# Altium schematic sheet Power.SchDoc(Power)
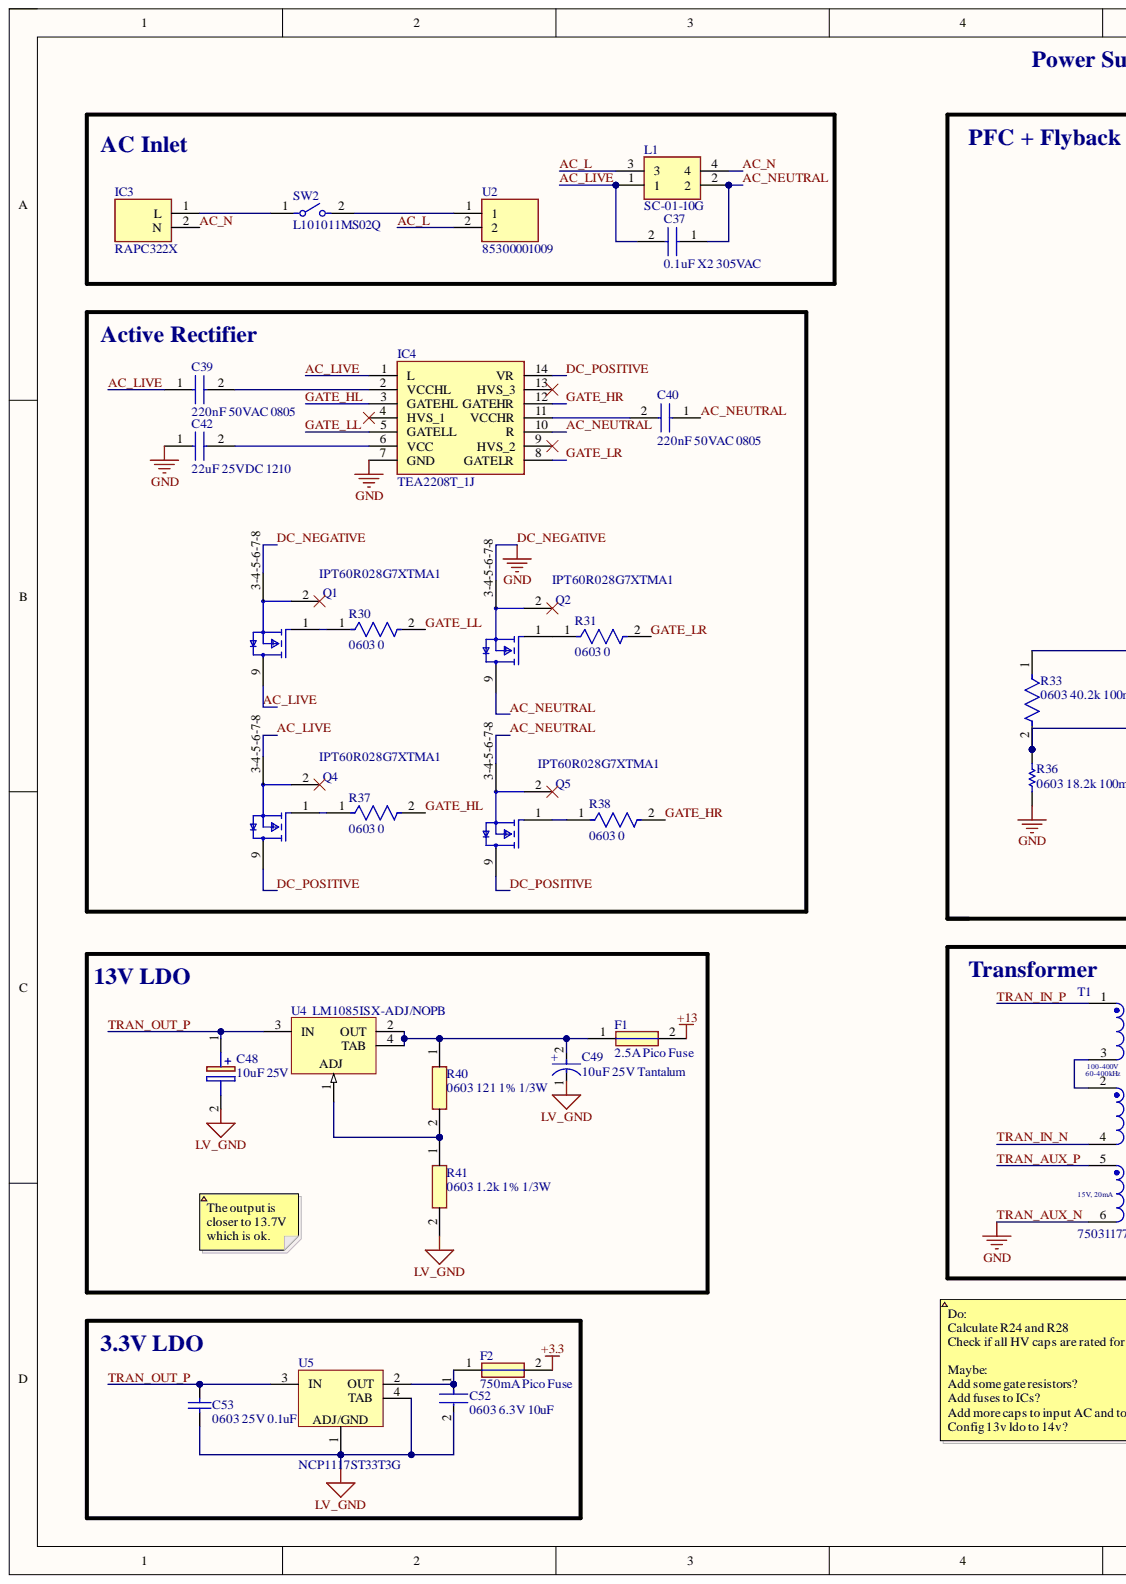
[diagram p0: Power.SchDoc, left]
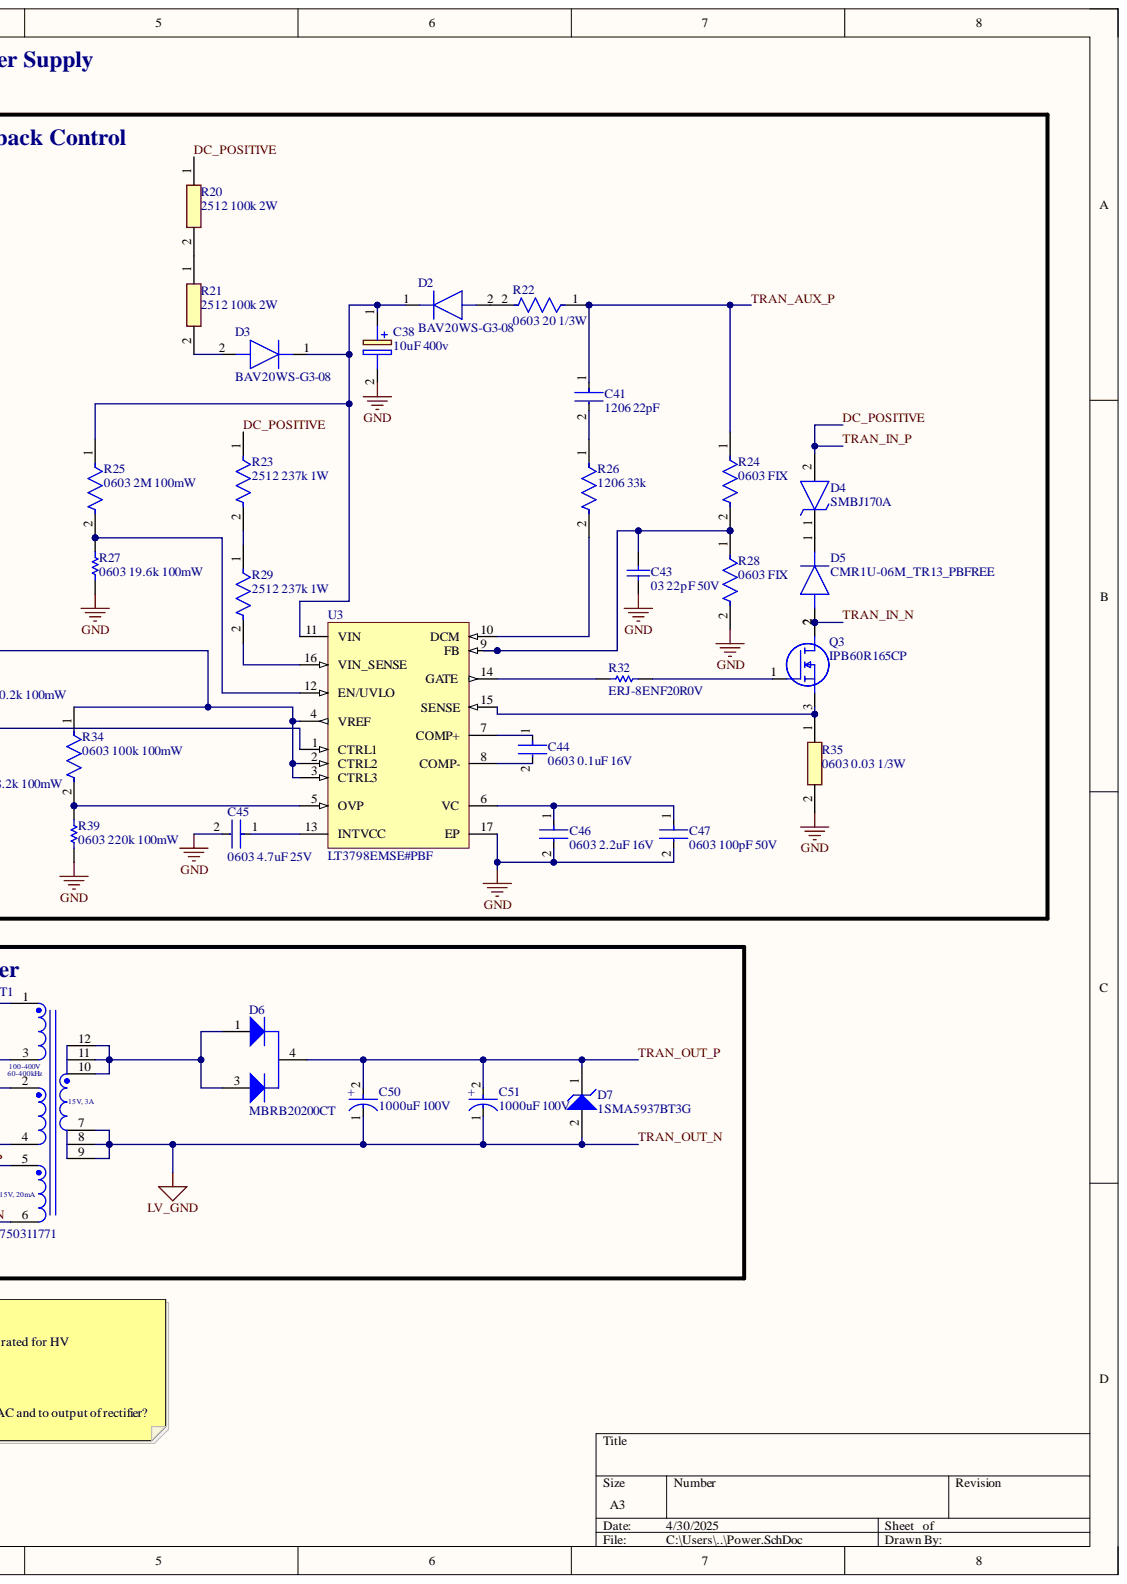
[diagram p1: Power.SchDoc, right]

## Parts
C37  0.1uF X2 305VAC  [B32922C_(18_x_5)]
C38  10uF 400v  [CAPPRD500W60D1025H2150]
C39  220nF 50VAC 0805  [CAPC2012X145N]
C40  220nF 50VAC 0805  [CAPC2012X145N]
C41  1206 22pF  value 22 pF  [FP-C1206C-EB-MFG]
C42  22uF 25VDC 1210  [CAPC3225X200N]
C43  03 22pF 50V  Capacitance: 22pF  [CAPC1608X90X35ML10T15]
C44  0603 0.1uF 16V  [FP-C0603C-CF-MFG]
C45  0603 4.7uF 25V  Capacitance: 4.7uF  Case Code (Imperial): 0603  [FP-GRM188-0_15-IPC_C]
C46  0603 2.2uF 16V  Capacitance: 2.2uF  Case Code (Imperial): 0603  [FP-C0603C225K4PACTU-MFG]
C47  0603 100pF 50V  value 100 pF  [FP-C0603C-CF-MFG]
C48  10uF 25V  [CAPPRD200W55D500H1200]
C49  10uF 25V Tantalum  Capacitance: 10uF  Voltage Rating: 25V  [FP-TAJB-MFG]
C50  1000uF 100V  [FP-RAD-TH-D_16_0_5-L_25_2-MFG]
C51  1000uF 100V  [FP-RAD-TH-D_16_0_5-L_25_2-MFG]
C52  0603 6.3V 10uF  Case Code (Imperial): 0603  Voltage: 6.3V  [FP-GRM188-0_1-e0_2_0_55-IPC_A]
C53  0603 25V 0.1uF  [CAPC1608X87X35LL15T15]
D2  BAV20WS-G3-08  [SOD2713X115N]
D3  BAV20WS-G3-08  [SOD2713X115N]
D4  SMBJ170A  [DIOM5336X244N]
D5  CMR1U-06M_TR13_PBFREE  [DIOM5226X262N]
D6  MBRB20200CT  [ONSC-D2PAK-3-418B-04_V]
D7  1SMA5937BT3G  [ONSC-SMA-2-403D-02_F_V]
F1  2.5A Pico Fuse  [0251004MAT1L]
F2  750mA Pico Fuse  [0251004MAT1L]
IC3  RAPC322X  [RAPC322X]
IC4  TEA2208T_1J  [SOIC127P600X175-14N]
L1  SC-01-10G  [SC0110G]
Q1  IPT60R028G7XTMA1  [FP-PG-HSOF-8-MFG]
Q2  IPT60R028G7XTMA1  [FP-PG-HSOF-8-MFG]
Q3  IPB60R165CP  [IPB60R165CP]
Q4  IPT60R028G7XTMA1  [FP-PG-HSOF-8-MFG]
Q5  IPT60R028G7XTMA1  [FP-PG-HSOF-8-MFG]
R20  2512 100k 2W  [RESC6432X65N]
R21  2512 100k 2W  [RESC6432X65N]
R22  0603 20 1/3W  Case Code (Imperial): 0603  [FP-CRCW0603-HPe3-IPC_B]
R23  2512 237k 1W  Case Code (Imperial): 2512  [FP-CRCW2512-e3-IPC_B]
R24  0603 FIX  Case Code (Imperial): 0603  [FP-CRCW0603-HPe3-MFG]
R25  0603 2M 100mW  Case Code (Imperial): 0603  [FP-CRCW0603-e3-IPC_A]
R26  1206 33k  Case Code (Imperial): 1206  [FP-CRCW1206-e3-IPC_B]
R27  0603 19.6k 100mW  [RESC1609X50X30NL10T20]
R28  0603 FIX  Case Code (Imperial): 0603  [FP-CRCW0603-HPe3-MFG]
R29  2512 237k 1W  Case Code (Imperial): 2512  [FP-CRCW2512-e3-IPC_B]
R30  0603 0  Package: 0603  [FP-ERJ-3GEY0R00V-MFG]
R31  0603 0  Package: 0603  [FP-ERJ-3GEY0R00V-MFG]
R32  ERJ-8ENF20R0V  [RESC3216X70X50NL05T20]
R33  0603 40.2k 100mW  Case Code (Imperial): 0603  [FP-RC0603-0_55-IPC_C]
R34  0603 100k 100mW  Case Code (Imperial): 0603  Max Power Dissipation: 100mW  [FP-RC0603-0_55-MFG]
R35  0603 0.03 1/3W  [ERJ3_B_BQ_BW_LW_(0603)]
R36  0603 18.2k 100mW  [RESC1608X55X30NL15T15]
R37  0603 0  Package: 0603  [FP-ERJ-3GEY0R00V-MFG]
R38  0603 0  Package: 0603  [FP-ERJ-3GEY0R00V-MFG]
R39  0603 220k 100mW  PackageReference: 0603  [RESC1608X55X25ML10T15]
R40  0603 121 1% 1/3W  [RESC1608X55N]
R41  0603 1.2k 1% 1/3W  [RESC1608X55N]
SW2  L101011MS02Q  [FP-L101011MS02Q-MFG]
T1  750311771  Output Current: 3A  [WE-FB_EFD25]
U2  85300001009  [85300001009]
U3  LT3798EMSE#PBF  [LT-MSE-16-EDP_L]
U4  LM1085ISX-ADJ/NOPB  [KTT0003B_M]
U5  NCP1117ST33T3G  [ONSC-SOT-223-4-318H-01_A_V]

## Nets
+3.3: F2.2
+13: F1.2
AC_L: L1.3 U2.2
AC_LIVE: C37.2 C39.1 IC4.1(L) L1.1 Q1.9 Q4.3-4-5-6-7-8
AC_N: IC3.2 L1.4
AC_NEUTRAL: C37.1 C40.1 IC4.10(R) L1.2 Q2.9 Q5.3-4-5-6-7-8
DC_NEGATIVE: C38.2 C42.1 C43.2 C45.2 C46.2 C47.2 IC4.7(GND) Q1.3-4-5-6-7-8 Q2.3-4-5-6-7-8 R27.1 R28.2 R35.2 R36.2 R39.2 T1.6 U3.17(EP)
DC_POSITIVE: D4.2 IC4.14(VR) Q4.9 Q5.9 R20.1 R23.1 T1.1
GATE_HL: IC4.3(GATEHL) R37.2
GATE_HR: IC4.12(GATEHR) R38.2
GATE_LL: IC4.5(GATELL) R30.2
GATE_LR: IC4.8(GATELR) R31.2
TRAN_AUX_P: C41.1 R22.1 R24.1 T1.5
TRAN_IN_N: D5.2 Q3.2 T1.4
TRAN_OUT_N: C48.2 C49.1 C50.1 C51.1 C52.2 C53.2 D7.2 R41.2 T1.7 T1.8 T1.9 U5.1 U5.4(TAB)
TRAN_OUT_P: C48.1 C50.2 C51.2 C53.1 D6.4 D7.1 U4.3(IN) U5.3(IN)
NetC38_1: C38.1 D2.1 D3.1 R25.1 U3.11(VIN)
NetC39_2: C39.2 IC4.2(VCCHL)
NetC40_2: C40.2 IC4.11(VCCHR)
NetC41_2: C41.2 R26.1
NetC42_2: C42.2 IC4.6(VCC)
NetC43_1: C43.1 R24.2 R28.1 U3.9(FB)
NetC44_1: C44.1 U3.7(COMP+)
NetC44_2: C44.2 U3.8(COMP-)
NetC45_1: C45.1 U3.13(INTVCC)
NetC46_1: C46.1 C47.1 U3.6(VC)
NetC49_2: C49.2 F1.1 R40.1 U4.2(OUT) U4.4(TAB)
NetC52_1: C52.1 F2.1 U5.2(OUT)
NetD2_2: D2.2 R22.2
NetD3_2: D3.2 R21.2
NetD4_1: D4.1 D5.1
NetD6_1: D6.1 D6.3 T1.10 T1.11 T1.12
NetIC3_1: IC3.1 SW2.1
NetQ1_1: Q1.1 R30.1
NetQ2_1: Q2.1 R31.1
NetQ3_1: Q3.1 R32.2
NetQ3_3: Q3.3 R35.1 U3.15(SENSE)
NetQ4_1: Q4.1 R37.1
NetQ5_1: Q5.1 R38.1
NetR20_2: R20.2 R21.1
NetR23_2: R23.2 R29.1
NetR25_2: R25.2 R27.2 U3.12(EN/UVLO)
NetR26_2: R26.2 U3.10(DCM)
NetR29_2: R29.2 U3.16(VIN_SENSE)
NetR32_1: R32.1 U3.14(GATE)
NetR33_1: R33.1 R34.1 U3.2(CTRL2) U3.3(CTRL3) U3.4(VREF)
NetR33_2: R33.2 R36.1 U3.1(CTRL1)
NetR34_2: R34.2 R39.1 U3.5(OVP)
NetR40_2: R40.2 R41.1 U4.1
NetSW2_2: SW2.2 U2.1
NetT1_2: T1.2 T1.3

Not connected: IC4 4(HVS_1) 9(HVS_2) 13(HVS_3); Q1 2; Q2 2; Q4 2; Q5 2

## Library data of the parts
C37 = B32922C3104K289: Capacitor; Manufacturer_Name: TDK; Arrow Part Number: B32922C3104K289; Arrow Price/Stock: https://www.arrow.com/en/products/b32922c3104k289/tdk?utm_currency=USD&region=nac; Component Kind: Standard; Datasheet Link: https://product.tdk.com/system/files/dam/doc/product/capacitor/film/emi/data_sheet/20/20/db/fc_2009/x2_b32921_928.pdf; Manufacturer_Part_Number: B32922C3104K289; Mouser Part Number: 871-B32922C3104K289; Mouser Price/Stock: https://www.mouser.co.uk/ProductDetail/EPCOS-TDK/B32922C3104K289?qs=w5o0Loj0u7OxRKa1IZTr8g%3D%3D
C38 = UCS2G100MPD1TD: Capacitor Polarised; Manufacturer_Name: Nichicon; Arrow Part Number: UCS2G100MPD1TD; Arrow Price/Stock: https://www.arrow.com/en/products/ucs2g100mpd1td/nichicon?region=nac; Component Kind: Standard; Datasheet Link: http://nichicon-us.com/english/products/pdfs/e-ucs.pdf; Height: 21.5mm; Manufacturer_Part_Number: UCS2G100MPD1TD; Mouser Part Number: 647-UCS2G100MPD1TD; Mouser Price/Stock: https://www.mouser.co.uk/ProductDetail/Nichicon/UCS2G100MPD1TD?qs=qJYTfuAnAfodP803qrfaZA%3D%3D
C39 C40 = AC0805KFX7R9BB224: Capacitor; Manufacturer_Name: KEMET; Component Kind: Standard; Datasheet Link: http://www.yageo.com/documents/recent/UPY-AC_NP0X7R_16V-to-630V_12.pdf; Height: 1.45mm; Manufacturer_Part_Number: AC0805KFX7R9BB224
C41 = CMP-1037-00989-2: CAP CER 22PF 100V 5% NP0 1206; Manufacturer: KEMET; Manufacturer Part Number: C1206C220J1GACTU; Automotive Grade: Grade 0; Material: Ceramic; Temperature Characteristic: C0G; Temperature Coefficient: 15%; Tolerance: 0.05; Automotive: Yes; Capacitance: 22 pF; Category: Cap; Component Kind: Standard; ComponentLink2Description: Datasheet; ComponentLink2URL: https://content.kemet.com/datasheets/KEM_C1007_X8R_ULTRA_150C_SMD.pdf; Device Class L1: Passive Components; Device Class L2: Capacitors; Device Class L3: Ceramic Capacitors; Digikey Description: CAP CER 22PF 100V 5% NP0 1206; Height: 0.88mm; Ipc Land Pattern Name: CAPC320160X78; Lead Free: Yes; Library Name: Altium Content Vault; Mouser Description: 1206 22pF Ceramic Multilayer Capacitor; 100VDC; 125degC; C0G Dielec; +/-5% SMD; Octopart: https://octopart.com/c1206c220j1gactu-kemet-9145181; Package: C1206C-EB; RoHS: TRUE; Temperature: -55°C to +150°C; Temperature Range High: +150°C; Temperature Range Low: -55°C; Value: 22 pF; Voltage Rating: 100 V
C42 = 25MU225MB23225: Capacitor; Manufacturer_Name: Rubycon; Component Kind: Standard; Datasheet Link: http://www.rubycon.co.jp/en/catalog/e_pdfs/pmlcap/e_MU.pdf; Height: 2mm; Manufacturer_Part_Number: 25MU225MB23225; Mouser Part Number: 232-25MU225MB23225; Mouser Price/Stock: https://www.mouser.co.uk/ProductDetail/Rubycon/25MU225MB23225?qs=T3oQrply3y80th0zXFlwOw%3D%3D
C43 = CMP-2000-04945-1: Chip Capacitor, 22 pF, +/- 5%, 50 V, -55 to 125 degC, 0603 (1608 Metric), RoHS, Tape and Reel; Manufacturer: Murata; Dielectric: C0G; Case Size/Dimension: 0603; Component Kind: Standard; Datasheet URL: https://datasheet.ciiva.com/11786/0900766b807a1cab-11786398.pdf; Datasheet Version: Jul-06; Library Name: Altium Content Vault; Manufacturer URL: http://www.murata.com/; Package Description: 2-Pin Surface Mount Device, Body 1.6 x 0.8 mm; Package Reference: 603; Rated Voltage: 50V; Tolerance (%): ±5%
C44 = CMP-2006-03061-2: CAP CER 0.1UF 50V X7R 0603; Manufacturer: KEMET; Manufacturer Part Number: C0603C104K5RACTU; Automotive Grade: Grade 1; Capacitance: 0.10 μF; Material: Ceramic; Temperature Coefficient: 30ppm/°C; Tolerance: 0.1; Value: 0.10 μF; Automotive: Yes; Category: Cap; Component Kind: Standard; ComponentLink2Description: Datasheet; ComponentLink2URL: https://api.kemet.com/component-edge/download/datasheet/C0603C100J5GACAUTO.pdf; Device Class L1: Passive Components; Device Class L2: Capacitors; Device Class L3: Ceramic Capacitors; Digikey Description: CAP CER 0.1UF 50V X7R 0603; Height: 0.87mm; Lead Free: Yes; Library Name: Altium Content Vault; Octopart: https://octopart.com/c0603c104k5ractu-kemet-139244; Package: C0603C-CF; RoHS: TRUE; Temperature: -55°C to +125°C; Temperature Characteristic: X7R; Temperature Range High: +125°C; Temperature Range Low: -55°C; Voltage Rating: 50 V
C45 = CMP-2006-02523-2: Chip Multilayer Ceramic Capacitors for General Purpose, 0603, 4.7uF, X5R, 15%, 10%, 25V; Case Code (Metric): 1608; Case/Package: 0603; Component Kind: Standard; Depth: 0.8mm; Dielectric: X5R; Height: 0.8mm; Lead Free: Lead Free; Length: 1.6mm; Library Name: Altium Content Vault; Material: Ceramic; Max Operating Temperature: 85°C; Min Operating Temperature: -55°C; Mount: Surface Mount; Package Quantity: 4000; Packaging: Cut Tape; REACH SVHC: No SVHC; Thickness: 0.031inch; Tolerance: 10%; Voltage: 25V; Voltage Rating: 25V; Voltage Rating (DC): 25V; Width: 0.031inch
C46 = CMP-2006-02259-2: CAP CER 2.2UF 16V X5R 0603; Dielectric Material: Ceramic; Dissipation Factor: 5%; Tolerance: 10%; Case Code (Metric): 1608; Case/Package: 0603; Component Kind: Standard; Construction: Flat; Contact Plating: Tin; Depth: 0.8mm; Dielectric: X5R; Height: 0.8mm; Lead Free: Lead Free; Length: 1.6mm; Library Name: Altium Content Vault; Max Operating Temperature: 85°C; Min Operating Temperature: -55°C; Mount: Surface Mount; Number of Pins: 2; Package Quantity: 1; Packaging: Tape and Reel; Radiation Hardening: No; REACH SVHC: No SVHC; RoHS Compliant: Yes; Series: C; Termination: SMD/SMT; Thickness: 0.034inch; Voltage: 16V; Voltage Rating: 16V; Voltage Rating (DC): 16V; Width: 0.8mm
C47 = CMP-2006-03408-2: CAP CER 100PF 50V C0G/NP0 0603; Manufacturer: KEMET; Manufacturer Part Number: C0603C101J5GACTU; Automotive Grade: Grade 1; Material: Ceramic; Temperature Characteristic: C0G; Temperature Coefficient: 30ppm/°C; Tolerance: 0.05; Automotive: Yes; Capacitance: 100 pF; Category: Cap; Component Kind: Standard; ComponentLink2Description: Datasheet; ComponentLink2URL: https://api.kemet.com/component-edge/download/datasheet/C0603C100J5GACAUTO.pdf; Device Class L1: Passive Components; Device Class L2: Capacitors; Device Class L3: Ceramic Capacitors; Digikey Description: CAP CER 100PF 50V C0G/NP0 0603; Height: 0.87mm; Lead Free: Yes; Library Name: Altium Content Vault; Mouser Description: Multilayer Ceramic Capacitor, C Series, 100 Pf, - 5%, C0g / Np0, 50 V, 0603 [1608 Metric] Rohs Compliant: Yes; Octopart: https://octopart.com/c0603c101j5gactu-kemet-100052; Package: C0603C-CF; RoHS: TRUE; Temperature: -55°C to +125°C; Temperature Range High: +125°C; Temperature Range Low: -55°C; Value: 100 pF; Voltage Rating: 50 V
C48 = ECA-1EM100: Capacitor Polarised; Manufacturer_Name: Panasonic; Arrow Part Number: ECA-1EM100; Arrow Price/Stock: https://www.arrow.com/en/products/eca1em100/panasonic; Component Kind: Standard; Datasheet Link: https://componentsearchengine.com/Datasheets/2/ECA-1EM100.pdf; Height: 12mm; Manufacturer_Part_Number: ECA-1EM100; Mouser Part Number: 667-ECA-1EM100; Mouser Price/Stock: https://www.mouser.com/Search/Refine.aspx?Keyword=667-ECA-1EM100
C49 = CMP-04424-000481-1: 10uF 25V  ±10% 2.5Ω 1210  SMD Tantalum Capacitor; Dissipation Factor: 6%; ESR (Equivalent Series Resistance): 2.5R; Leakage Current: 2.5uA; Polarity: Polar; Case Code (Imperial): 1210; Case Code (Metric): 3528; Case/Package: 1210; Component Kind: Standard; Construction: Flat; Depth: 2.8mm; Features: General Purpose; Height: 2.1mm; Height - Seated (Max): 0.083inch; Lead Free: Lead Free; Length: 3.5mm; Library Name: Altium Content Vault; Max Operating Temperature: 125°C; Min Operating Temperature: -55°C; Mount: Surface Mount; Package Quantity: 1; Packaging: Tape and Reel; Radiation Hardening: No; REACH SVHC: No SVHC; RoHS Compliant: Yes; Series: TAJ; Termination: SMD/SMT; Tolerance: 10%; Voltage Rating (DC): 25V; Weight: 0.000564oz; Width: 0.11inch
C50 C51 = CMP-05427-000487-1: Aluminum Electrolytic Capacitors (Radial Lead Type) 1000uF ±20% 50V; Capacitance: 1mF; ESR (Equivalent Series Resistance): 16mR; Lead Diameter: 0.8mm; Life (Hours): 10000Hour; Polarity: Polar; Ripple Current: 3.32A; Case/Package: Radial; Component Kind: Standard; Contact Plating: Tin; Diameter: 16mm; Features: General Purpose; Height: 25mm; Height - Seated (Max): 0.984inch; Impedance: 16mR; Lead Free: Lead Free; Lead Pitch: 7.5mm; Length: 25mm; Library Name: Altium Content Vault; Max Operating Temperature: 105°C; Min Operating Temperature: -40°C; Mount: Through Hole; Number of Pins: 2; Package Quantity: 250; Packaging: Tape and Reel; Radiation Hardening: No; REACH SVHC: No SVHC; RoHS Compliant: Yes; Series: FR; Termination: Through Hole; Tolerance: 20%; Voltage Rating: 50V; Voltage Rating (DC): 50V
C52 = CMP-2006-02792-2: Chip Multilayer Ceramic Capacitors for General Purpose, 0603, 10uF, X5R, 15%, 20%, 6.3V; Capacitance: 10uF; Case Code (Metric): 1608; Case/Package: 0603; Component Kind: Standard; Depth: 0.8mm; Dielectric: X5R; Height: 0.8mm; Lead Free: Lead Free; Length: 1.6mm; Library Name: Altium Content Vault; Material: Ceramic; Max Operating Temperature: 85°C; Min Operating Temperature: -55°C; Mount: Surface Mount; Package Quantity: 4000; Packaging: Tape and Reel; Radiation Hardening: No; REACH SVHC: No SVHC; Termination: SMD/SMT; Thickness: 0.035inch; Tolerance: 20%; Voltage Rating: 6.3V; Voltage Rating (DC): 6.3V; Width: 0.031inch
C53 = CMP-2006-03713-1: Manufacturer: Kemet; Part Number: C0603C105K3RACTU; Component Kind: Standard; Library Name: Altium Content Vault
D2 D3 = BAV20WS-G3-08: Diode; Manufacturer_Name: Vishay; Arrow Part Number: BAV20WS-G3-08; Arrow Price/Stock: https://www.arrow.com/en/products/bav20ws-g3-08/vishay?region=nac; Component Kind: Standard; Datasheet Link: https://componentsearchengine.com/Datasheets/1/BAV20WS-G3-08.pdf; Height: 1.15mm; Library Name: SamacSys.SchLib; Manufacturer_Part_Number: BAV20WS-G3-08; Mouser Part Number: 78-BAV20WS-G3-08; Mouser Price/Stock: https://www.mouser.co.uk/ProductDetail/Vishay-Semiconductors/BAV20WS-G3-08?qs=ROh%252BA47s9d2Jk9K4pPeq%252BQ%3D%3D
D4 = SMBJ170A: TVS Diode (Uni-directional); Manufacturer_Name: HY Electronic; Component Kind: Standard; Height: 2.44mm; Library Name: SamacSys.SchLib; Manufacturer_Part_Number: SMBJ170A
D5 = CMR1U-06M_TR13_PBFREE: Diode; Manufacturer_Name: Central Semiconductor; Manufacturer_Part_Number: CMR1U-06M TR13 PBFREE; Component Kind: Standard; Datasheet Link: https://my.centralsemi.com/datasheets/CMR1U01M.PDF; Height: 2.62mm; Library Name: SamacSys.SchLib; Mouser Part Number: 610-CMR1U-06M; Mouser Price/Stock: https://www.mouser.co.uk/ProductDetail/Central-Semiconductor/CMR1U-06M-TR13-PBFREE/?qs=u16ybLDytRaiTbcu0RAPhw%3D%3D
D6 = CMP-1055-00130-1: SWITCHMODE(TM) Power Rectifier, Dual Schottky Rectifiers, 3-Pin D2PAK, Tube; Manufacturer: On Semiconductor; Configuration: Common Cathode; IFSM Max (A): 150; Note1: 0; Component Kind: Standard; ComponentLink1Description: Manufacturer URL; ComponentLink1URL: http://www.onsemi.com/; ComponentLink2Description: Datasheet; ComponentLink2URL: http://www.onsemi.cn/pub_link/Collateral/MBRB20200CT-D.PDF; ComponentLink3Description: Package Specification; ComponentLink3URL: http://www.onsemi.com/pub_link/Collateral/418B-04.PDF; DatasheetVersion: Rev. 7; Library Name: Altium Content Vault; Mounting Technology: Surface Mount; PackageDescription: 3-Pin Flange Mount, Body 9.145 x 9.97 mm, Pitch 2.54 mm; PackageReference: D2PAK-3-418B-04; PackageVersion: Rev. K, 09/2008; Packing: Tube; PartNumber: MBRB20200CT; RoHS: FALSE
D7 = CMP-1060-00094-1: 1.5 W Zener Voltage Regulator, 2-Pin SMA, Pb-Free, Tape and Reel; Manufacturer: On Semiconductor; VZ Typ (V): 33; Component Kind: Standard; ComponentLink1Description: Manufacturer URL; ComponentLink1URL: http://www.onsemi.com/; ComponentLink2Description: Datasheet; ComponentLink2URL: http://www.onsemi.com/pub_link/Collateral/1SMA5913BT3-D.PDF; ComponentLink3Description: Package Specification; ComponentLink3URL: http://www.onsemi.com/pub_link/Collateral/1SMA5913BT3-D.PDF; DatasheetVersion: Rev. 8; Library Name: Altium Content Vault; Mounting Technology: Surface Mount; PackageDescription: 2-Pin Small Molded Package, J-Bend Lead, Body 2.605 x 4.315 mm; PackageReference: SMA-2-403D-02_F; PackageVersion: Rev. F, 11/2008; Packing: Tape and Reel; PartNumber: 1SMA5937BT3G; RoHS: Pb-Free
F1 F2 = 0251004.MAT1L: Fuse; Manufacturer_Name: LITTELFUSE; Arrow Part Number: 0251004.MAT1L; Arrow Price/Stock: https://www.arrow.com/en/products/0251004.mat1l/littelfuse?utm_currency=USD&region=nac; Component Kind: Standard; Datasheet Link: https://www.littelfuse.com/media?resourcetype=datasheets&itemid=f47a0bb7-8ede-4679-9646-7114c3787688&filename=littelfuse-fuse-251-253-datasheet; Height: 2.8mm; Manufacturer_Part_Number: 0251004.MAT1L; Mouser Part Number: 576-0251004.MAT1L; Mouser Price/Stock: https://www.mouser.co.uk/ProductDetail/Littelfuse/0251004.MAT1L?qs=vco6xy%252BeH1onbv8s0e1iMg%3D%3D
IC3 = RAPC322X: Integrated Circuit; Manufacturer_Name: Switchcraft; Arrow Part Number: RAPC322X; Arrow Price/Stock: https://www.arrow.com/en/products/rapc322x/switchcraft?region=nac; Component Kind: Standard; Datasheet Link: http://www.switchcraft.com/Drawings/RAPC322X_CD.PDF; Height: 13mm; Manufacturer_Part_Number: RAPC322X; Mouser Part Number: 502-RAPC322X; Mouser Price/Stock: https://www.mouser.co.uk/ProductDetail/Switchcraft/RAPC322X?qs=mcPJWgAPNrcmmHrLtKpxWA%3D%3D
IC4 = TEA2208T_1J: Integrated Circuit; Manufacturer_Name: NXP; Manufacturer_Part_Number: TEA2208T/1J; Component Kind: Standard; Datasheet Link: https://www.nxp.com/docs/en/data-sheet/TEA2208T.pdf; Height: 1.75mm; Mouser Part Number: 771-TEA2208T/1J; Mouser Price/Stock: https://www.mouser.co.uk/ProductDetail/NXP-Semiconductors/TEA2208T-1J?qs=wnTfsH77Xs7i9IS7OBVq2w%3D%3D
L1 = SC-01-10G: Inductor; Manufacturer_Name: KEMET; Component Kind: Standard; Datasheet Link: https://content.kemet.com/datasheets/KEM_LF0011_SC-G-GS.pdf; Height: 23mm; Manufacturer_Part_Number: SC-01-10G; Mouser Part Number: 80-SC-01-10G; Mouser Price/Stock: https://www.mouser.co.uk/ProductDetail/KEMET/SC-01-10G?qs=BenOyfdfArpzDVRBtNEKMw%3D%3D
Q1 Q2 Q4 Q5 = CMP-32901-000081-1: MOSFET N-CH 600V 75A HSOF-8; Manufacturer: Infineon; Depletion Mode: False; Drain To Source Resistance: 0.024Ω; Drain To Source Voltage: 650V; Gate Charge At Vgs: 123nC @ 10V; Gate To Source Voltage: 20V; Input Capacitace At Vds: 4820pF @ 400V; Max Junction Temp: +150°C; Number Of Channels: 1; Power Dissipation: 391W; Pulse Drain Current: 245A; Reverse Recovery Charge: 8700nC; Reverse Recovery Time: 440ns; Rthja Max: 62°C/W; Threshold Vgs Max: 4V; Threshold Vgs Min: 3V; Turn Off Delay Time: 100ns; Turn On Delay Time: 28ns; Typ Forward Diode Voltage: 0.8V; Automotive: No; Category: Trans; Component Kind: Standard; ComponentLink2Description: Datasheet; ComponentLink2URL: https://www.infineon.com/dgdl/Infineon-IPT60R028G7-DS-v02_00-EN.pdf?fileId=5546d46259d9a4bf015a13e82df70e30; Continuous Drain Current: 75A; Device Class L1: Discrete Semiconductors; Device Class L2: Transistors; Device Class L3: MOSFETs; Digikey Description: MOSFET N-CH 600V 75A HSOF-8; Drain To Source Breakdown Voltage: 600V; Footprint Url: https://www.infineon.com/cms/en/product/packages/PG-HSOF/PG-HSOF-8-2/; Height: 2.4mm; Lead Free: Yes; Library Name: Altium Content Vault; Manufacturer Part Number: IPT60R028G7XTMA1; Mouser Description: Trans MOSFET N-CH 600V 75A 9-Pin(8+Tab) HSOF T/R; Number Of N Channels: 1; Octopart: https://octopart.com/ipt60r028g7xtma1-infineon-76958210; Package: PG-HSOF-8; RoHS: TRUE; Temperature: -55°C to +150°C; Temperature Range High: +150°C; Temperature Range Low: -55°C
Q3 = IPB60R165CP: MOSFET (N-Channel); Manufacturer_Name: Infineon; Component Kind: Standard; Datasheet Link: https://www.infineon.com/dgdl/Infineon-IPB60R165CP-DS-v02_01-en.pdf?fileId=db3a304412b407950112b42dd38f48fc; Height: 4.826mm; Library Name: SamacSys.SchLib; Manufacturer_Part_Number: IPB60R165CP; Mouser Part Number: 726-IPB60R165CP; Mouser Price/Stock: https://www.mouser.co.uk/ProductDetail/Infineon-Technologies/IPB60R165CP?qs=mzcOS1kGbgdcrfUvmAosLQ%3D%3D
R20 R21 = 9-2176070-7: Resistor; Manufacturer_Name: TE Connectivity; Component Kind: Standard; Datasheet Link: https://www.te.com/commerce/DocumentDelivery/DDEController?Action=srchrtrv&DocNm=9-1773463-5&DocType=Data+Sheet&DocLang=English&PartCntxt=9-2176070-7&DocFormat=pdf; Height: 0.65mm; Manufacturer_Part_Number: 9-2176070-7; Mouser Part Number: 279-9-2176070-7; Mouser Price/Stock: https://www.mouser.co.uk/ProductDetail/TE-Connectivity-Holsworthy/9-2176070-7/?qs=IXkKOuu5vnGY%252Bze3ajKOBA%3D%3D
R22 = CMP-2000-03074-2: RES Thick Film, 20Ω, 1%, 0.33W, 100ppm/°C, 0603; Max Power Dissipation: 250mW; Resistance: 20R; Voltage Rating: 75V; Case Code (Metric): 1608; Case/Package: 0603; Component Kind: Standard; Composition: Thick Film; Contact Plating: Tin; Depth: 0.85mm; Features: Automotive AEC-Q200; Height: 0.45mm; Lead Free: Lead Free; Length: 1.6mm; Library Name: Altium Content Vault; Max Operating Temperature: 155°C; Min Operating Temperature: -55°C; Mount: Surface Mount; Number of Terminations: 2; Package Quantity: 10; Packaging: Tape and Reel; Power Rating: 250mW; Radiation Hardening: No; Temperature Coefficient: 100ppm/°C; Termination: Wraparound; Tolerance: 1%; Weight: 7.1E-05oz; Width: 0.033inch
R23 R29 = CMP-02407-005674-1: RES Thick Film, 237kΩ, 1%, 1W, 100ppm/°C, 2512; Resistance: 237kR; Voltage Rating (DC): 500V; Case Code (Metric): 6432; Case/Package: 2512; Component Kind: Standard; Composition: Thick Film; Features: Automotive AEC-Q200; Height: 0.028inch; Lead Free: Lead Free; Length: 0.248inch; Library Name: Altium Content Vault; Max Power Dissipation: 1W; Number of Terminations: 2; Package Quantity: 2000; Packaging: Tape and Reel; Temperature Coefficient: 100ppm/°C; Tolerance: 1%; Width: 0.124inch
R24 R28 = CMP-2000-03817-2: RES Thick Film, 0Ω, , 0.33W, , 0603; Max Power Dissipation: 250mW; Resistance: 0mR; Temperature Coefficient: 0ppm/°C; Voltage Rating: 75V; Case Code (Metric): 1608; Case/Package: 0603; Component Kind: Standard; Composition: Thick Film; Contact Plating: Tin; Depth: 0.85mm; Features: Automotive AEC-Q200; Height: 0.45mm; Lead Free: Lead Free; Length: 1.6mm; Library Name: Altium Content Vault; Max Operating Temperature: 155°C; Military Standard: Not; Min Operating Temperature: -55°C; Mount: Surface Mount; Number of Pins: 2; Number of Terminations: 2; Package Quantity: 5000; Packaging: Tape and Reel; Power Rating: 250mW; Radiation Hardening: No; Termination: SMD/SMT; Voltage Rating (DC): 75V; Weight: 7.1E-05oz; Width: 0.033inch
R25 = CMP-2000-03189-2: RES Thick Film, 2MΩ, 1%, 0.1W, 100ppm/°C, 0603; Resistance: 2MR; Voltage Rating: 75V; Case Code (Metric): 1608; Case/Package: 0603; Component Kind: Standard; Composition: Thick Film; Contact Plating: Tin; Depth: 0.85mm; Features: Automotive AEC-Q200; Height: 0.45mm; Lead Free: Lead Free; Length: 1.55mm; Library Name: Altium Content Vault; Max Operating Temperature: 155°C; Max Power Dissipation: 100mW; Military Standard: Not; Min Operating Temperature: -55°C; Number of Pins: 2; Number of Terminations: 2; Package Quantity: 10; Packaging: Tape and Reel; Power Rating: 100mW; Radiation Hardening: No; Temperature Coefficient: 100ppm/°C; Termination: SMD/SMT; Tolerance: 1%; Voltage Rating (DC): 75V; Weight: 7.1E-05oz; Width: 0.033inch; Working Voltage: 75V
R26 = CMP-2003-02103-2: RES Thick Film, 33kΩ, 1%, 0.25W, 100ppm/°C, 1206; Max Power Dissipation: 250mW; Resistance: 33kR; Voltage Rating: 200V; Case Code (Metric): 3216; Case/Package: 1206; Component Kind: Standard; Composition: Thick Film; Contact Plating: Tin; Depth: 1.6mm; Features: Automotive AEC-Q200; Height: 0.55mm; Lead Free: Lead Free; Length: 3.2mm; Library Name: Altium Content Vault; Max Operating Temperature: 155°C; Military Standard: Not; Min Operating Temperature: -55°C; Number of Terminations: 2; Package Quantity: 10; Packaging: Tape and Reel; Power Rating: 250mW; Radiation Hardening: No; Temperature Coefficient: 100ppm/°C; Termination: SMD/SMT; Tolerance: 1%; Voltage Rating (DC): 200V; Weight: 0.000353oz; Width: 1.6mm; Working Voltage: 200V
R27 = CMP-2000-02969-1: Manufacturer: Vishay Dale; Part Number: CRCW060319K6FKEA; Component Kind: Standard; Library Name: Altium Content Vault
R30 R31 R37 R38 = CMP-2000-00700-2: RES SMD 0 OHM JUMPER 1/10W 0603; Manufacturer: Panasonic; Manufacturer Part Number: ERJ-3GEY0R00V; Automotive Grade: Grade 0; Power Rating: 0.1W; Resistance: 0Ω; Temperature Coefficient: -100/+600ppm/°C; Tolerance: 5%; Value: 0Ω; Voltage Rating: 75V; Automotive: Yes; Category: Res; Component Kind: Standard; ComponentLink2Description: Datasheet; ComponentLink2URL: https://industrial.panasonic.com/cdbs/www-data/pdf/RDA0000/AOA0000C301.pdf; Composition: Thick Film; Device Class L1: Passive Components; Device Class L2: Resistors; Device Class L3: Chip SMD Resistors; Digikey Description: RES SMD 0 OHM JUMPER 1/10W 0603; Footprint Url: https://industrial.panasonic.com/cdbs/www-data/pdf/RDA0000/DMA0000COL17.pdf; Height: 0.55mm; Ipc Land Pattern Name: RESC16080X45; Lead Free: Yes; Library Name: Altium Content Vault; Mouser Description: Resistor, Chip Thick Film, 0 Ohm, 0.1w, Resistor, Chip Thick Film, 0 Ohm, 0.1w, 5%; Octopart: https://octopart.com/erj-3gey0r00v-panasonic-55389238; RoHS: TRUE; Series: ERJ; Temperature: -55°C to +155°C; Temperature Range High: +155°C; Temperature Range Low: -55°C
R32 = CMP-2003-00203-1: Manufacturer: Panasonic; Component Kind: Standard; Library Name: Altium Content Vault; Part Number: ERJ-8ENF20R0V
R33 = CMP-03412-037816-1: RES 40.2K OHM 1% 1/10W 0603; Resistance: 40.2kR; Temperature Coefficient: 100ppm/°C; Voltage Rating: 50V; Working Voltage: 75V; Case Code (Metric): 1608; Case/Package: 0603; Component Kind: Standard; Construction: Rectangular; Depth: 0.8mm; Features: Moisture Resistant; Height: 0.45mm; Lead Free: Lead Free; Length: 1.6mm; Library Name: Altium Content Vault; Max Operating Temperature: 155°C; Max Power Dissipation: 100mW; Military Standard: Not; Min Operating Temperature: -55°C; Mount: Surface Mount; Number of Terminations: 2; Packaging: Tape and Reel; Power Rating: 100mW; Resistor Type: Thick Film; RoHS Compliant: Yes; Series: RC; Technology: METAL OXIDE FILM; Termination: SMD/SMT; Tolerance: 1%; Voltage Rating (DC): 50V; Width: 0.8mm
R34 = CMP-2100-03662-2: RES SMD 100K OHM 1% 1/10W 0603; Resistance: 100kR; Temperature Coefficient: 100ppm/°C; Voltage Rating: 50V; Working Voltage: 75V; Case Code (Metric): 1608; Case/Package: 0603; Component Kind: Standard; Construction: Rectangular; Depth: 0.8mm; Features: Moisture Resistant; Height: 0.45mm; Lead Free: Lead Free; Length: 1.6mm; Library Name: Altium Content Vault; Max Operating Temperature: 155°C; Military Standard: Not; Min Operating Temperature: -55°C; Number of Pins: 2; Number of Terminations: 2; Packaging: Tape and Reel; Power Rating: 100mW; REACH SVHC: No SVHC; Resistance Tolerance: 1%; Resistor Type: Thick Film; RoHS Compliant: Yes; Series: RC; Technology: THICK FILM; Termination: SMD/SMT; Tolerance: 1%; Voltage Rating (DC): 50V; Width: 0.8mm
R35 = ERJ-3BWFR030V: Resistor; Manufacturer_Name: Panasonic; Component Kind: Standard; Datasheet Link: http://industrial.panasonic.com/cdbs/www-data/pdf/RDN0000/AOA0000C313.pdf; Manufacturer_Part_Number: ERJ-3BWFR030V; Mouser Part Number: 667-ERJ-3BWFR030V; Mouser Price/Stock: https://www.mouser.co.uk/ProductDetail/Panasonic/ERJ-3BWFR030V?qs=ob%252BdNz2%252BYEgeP2PEealD%252Bg%3D%3D
R36 = CMP-2000-00300-1: Manufacturer: Panasonic; Part Number: ERJ-3EKF1822V; Component Kind: Standard; Library Name: Altium Content Vault
R39 = CMP-1659-00037-1: Chip Resistor, 220 KOhm, +/- 1%, 100 mW, -55 to 155 degC, 0603 (1608 Metric), RoHS, Tape and Reel; Resistance: 0.1 Ohm; Component Kind: Standard; ComponentLink1Description: Manufacturer URL; ComponentLink1URL: http://www.yageo.com/; ComponentLink2Description: Datasheet; ComponentLink2URL: http://www.yageo.com/documents/recent/PYu-RC_Group_51_RoHS_L_04.pdf; DatasheetVersion: Rev. 4, 02/2016; Height: 0.25 inch; Length: 0.75 inch; Library Name: Altium Content Vault; Max Operating Temperature: 70 degC; Min Operating Temperature: -25 degC; PackageDescription: 2-Pin Surface Mount Device, Body 1.6 x 0.8 mm; REACH SVHC: No SVHC; RoHSCompliant: true; Width: 0.25 inch
R40 = CRCW0603121RFKEAHP: Resistor; Manufacturer_Name: Vishay; Arrow Part Number: CRCW0603121RFKEAHP; Arrow Price/Stock: https://www.arrow.com/en/products/crcw0603121rfkeahp/vishay?region=nac; Component Kind: Standard; Datasheet Link: https://www.vishay.com/docs/20043/crcwhpe3.pdf; Height: 0.55mm; Manufacturer_Part_Number: CRCW0603121RFKEAHP; Mouser Part Number: 71-CRCW0603121RFKEAH; Mouser Price/Stock: https://www.mouser.co.uk/ProductDetail/Vishay-Dale/CRCW0603121RFKEAHP?qs=40TFAHZMFvf7JpHs6aBGEg%3D%3D
R41 = CRCW06031K20FKEAHP: Resistor; Manufacturer_Name: Vishay; Arrow Part Number: CRCW06031K20FKEAHP; Arrow Price/Stock: https://www.arrow.com/en/products/crcw06031k20fkeahp/vishay?region=nac; Component Kind: Standard; Datasheet Link: https://www.vishay.com/docs/20043/crcwhpe3.pdf; Height: 0.55mm; Manufacturer_Part_Number: CRCW06031K20FKEAHP; Mouser Part Number: 71-CRCW06031K20FKEAH; Mouser Price/Stock: https://www.mouser.co.uk/ProductDetail/Vishay-Dale/CRCW06031K20FKEAHP?qs=40TFAHZMFveP9B2Fb67Ndg%3D%3D
SW2 = CMP-10038-000020-1: SWITCH SLIDE SPST 4A 125V; Actuator Color: Black; Actuator Length: 5.08mm; Actuator Material: Nylon; Actuator Type: Standard; Contact Current Rating: 2A; Electrical Life: 10000Cycles; Max Voltage Rating (AC): 250V; Switch Function: On-Off; Switch Travel: 3.81mm; Voltage Rating (DC): 28V; Component Kind: Standard; Contact Material: Copper; Contact Plating: Silver; Current Rating: 4A; Depth: 10.8mm; Housing Material: Nylon; Lead Free: Lead Free; Length: 19.1mm; Library Name: Altium Content Vault; Max Current Rating: 4A; Max Operating Temperature: 65°C; Max Voltage Rating (DC): 125V; Min Operating Temperature: -30°C; Mount: Through Hole; Orientation: Straight; Package Quantity: 1; Packaging: Bulk; Radiation Hardening: No; RoHS Compliant: Yes; Series: L; Termination: PC Pins; Throw Configuration: SPST; Voltage Rating (AC): 125V
T1 = CMP-1360-00010-2: NexarPartId: 19122558; Current Rating: 2.5A; DC Resistance (DCR): 17mΩ; Frequency: 400kHz; Inductance: 500µH; Input Current: 100A; Isolation Voltage: 2.5kV; Max Input Voltage: 400V; Min Input Voltage: 100V; Output Power: 45W; Output Voltage: 15V; Turns Ratio: 6:1; Component Kind: Standard; Depth: 13.69mm; Height: 13.69mm; Height - Seated (Max): 13.69mm; Length: 27.03mm; Library Name: Altium Content Vault; Max DC Current: 2.5A; Max Operating Temperature: 125°C; Max Switching Frequency: 400kHz; Min Operating Temperature: -40°C; Mount: Surface Mount; Packaging: Digi-Reel®; REACH SVHC: No SVHC; RoHS: Compliant; Termination: SMD/SMT; Width: 32.31mm
U2 = 85300001009: Undefined or Miscellaneous; Manufacturer_Name: LITTELFUSE; Arrow Part Number: 85300001009; Arrow Price/Stock: https://www.arrow.com/en/products/85300001009/littelfuse; Component Kind: Standard; Datasheet Link: https://www.digikey.ph/en/products/detail/littelfuse-inc/85300001009/653461; Height: 32.9mm; Manufacturer_Part_Number: 85300001009; Mouser Part Number: 576-85300001009; Mouser Price/Stock: https://www.mouser.co.uk/ProductDetail/Littelfuse/85300001009?qs=ImUY93axo1htCnreTZ0QOw%3D%3D
U3 = CMP-0491-01149-1: Isolated No Opto-Coupler Flyback Controller with Active PFC, 16-pin SOP (MSE-16-EDP), -40 to 125 degC, Pb-Free; Manufacturer: Linear Technology; Number of Outputs: 1; Component Kind: Standard; ComponentLink1Description: Manufacturer URL; ComponentLink1URL: http://www.linear.com/; ComponentLink2Description: Datasheet; ComponentLink2URL: http://cds.linear.com/docs/en/datasheet/3798fa.pdf; ComponentLink3Description: Package Specification; ComponentLink3URL: http://cds.linear.com/docs/en/packaging/05081667_F_MSE16.pdf; DatasheetVersion: Rev. A; Library Name: Altium Content Vault; Mounting Technology: Surface Mount; PackageDescription: 16-Pin Plastic MSOP, Exposed Die Pad, Body 4.039 x 3 mm, Pitch 0.5 mm; PackageReference: MSE-16; PackageVersion: Rev. F, 05/2013; PartNumber: LT3798EMSE#PBF; RoHS: TRUE
U4 = CMP-0062-00598-5: 3A Low Dropout Positive Regulators, 3-pin TO-263, Pb-Free; Manufacturer: Texas Instruments; CompType: LDO IC; High-Reliability: Y; PackageTopMarking: NSUZXYTTE#*LM1085*IS-ADJ; PowerWise: N; Code_JEDEC: MO-169-AA; Component Kind: Standard; ComponentLink1Description: Datasheet URL; ComponentLink1URL: http://www.ti.com/general/docs/lit/getliterature.tsp?genericPartNumber=LM1085&fileType=pdf; Library Name: Altium Content Vault; PackageDescription: TO-263, Molded, .400 x .390 x .180in, 3 Lead, .1in Pitch, Surface Mount; PackageReference: KTT0003B; PartNumber: LM1085ISX-ADJ/NOPB; PinCount: 3; RoHS: Y
U5 = CMP-1679-00010-1: Low-Dropout Positive Fixed Voltage Regulator, 1 A, 3.3 V Output Voltage, 0 to 125 degC, 4-Pin SOT-223 (318H-01), Pb-Free, Tape and Reel; Case\Package: 0603; Power Rating: 100 mW; Resistance: 220 kohm; Tolerance: 1%; Type (Resistor): Thick Film; Voltage Rating: 75 V; Case Code (Imperial): 0603; Case Code (Metric): 1608; Component Kind: Standard; ComponentLink1Description: Manufacturer URL; ComponentLink1URL: http://www.onsemi.com/; ComponentLink2Description: Datasheet; ComponentLink2URL: http://www.onsemi.com/pub_link/Collateral/NCP1117-D.PDF; DatasheetVersion: Rev. 27, 09/2014; Height: 0.45 mm; Length: 1.55 mm; Library Name: Altium Content Vault; Max Operating Temperature: 155 degC; Min Operating Temperature: -55 degC; Mount: Surface Mount; Package Quantity: 5000; PackageDescription: 4-Pin Small Outline Transistor, Body 6.5 x 3.5 mm, Pitch 2.3 mm; PackageReference: SOT-223-4-318H-01_A; Packaging: Tape and Reel; Radiation Hardening: No; REACH SVHC: Unknown; RoHSCompliant: true; Weight: 7.1E-05 oz; Width: 0.85 mm
